# Altium schematic sheet Experiment 1 0.0.0.SchDoc
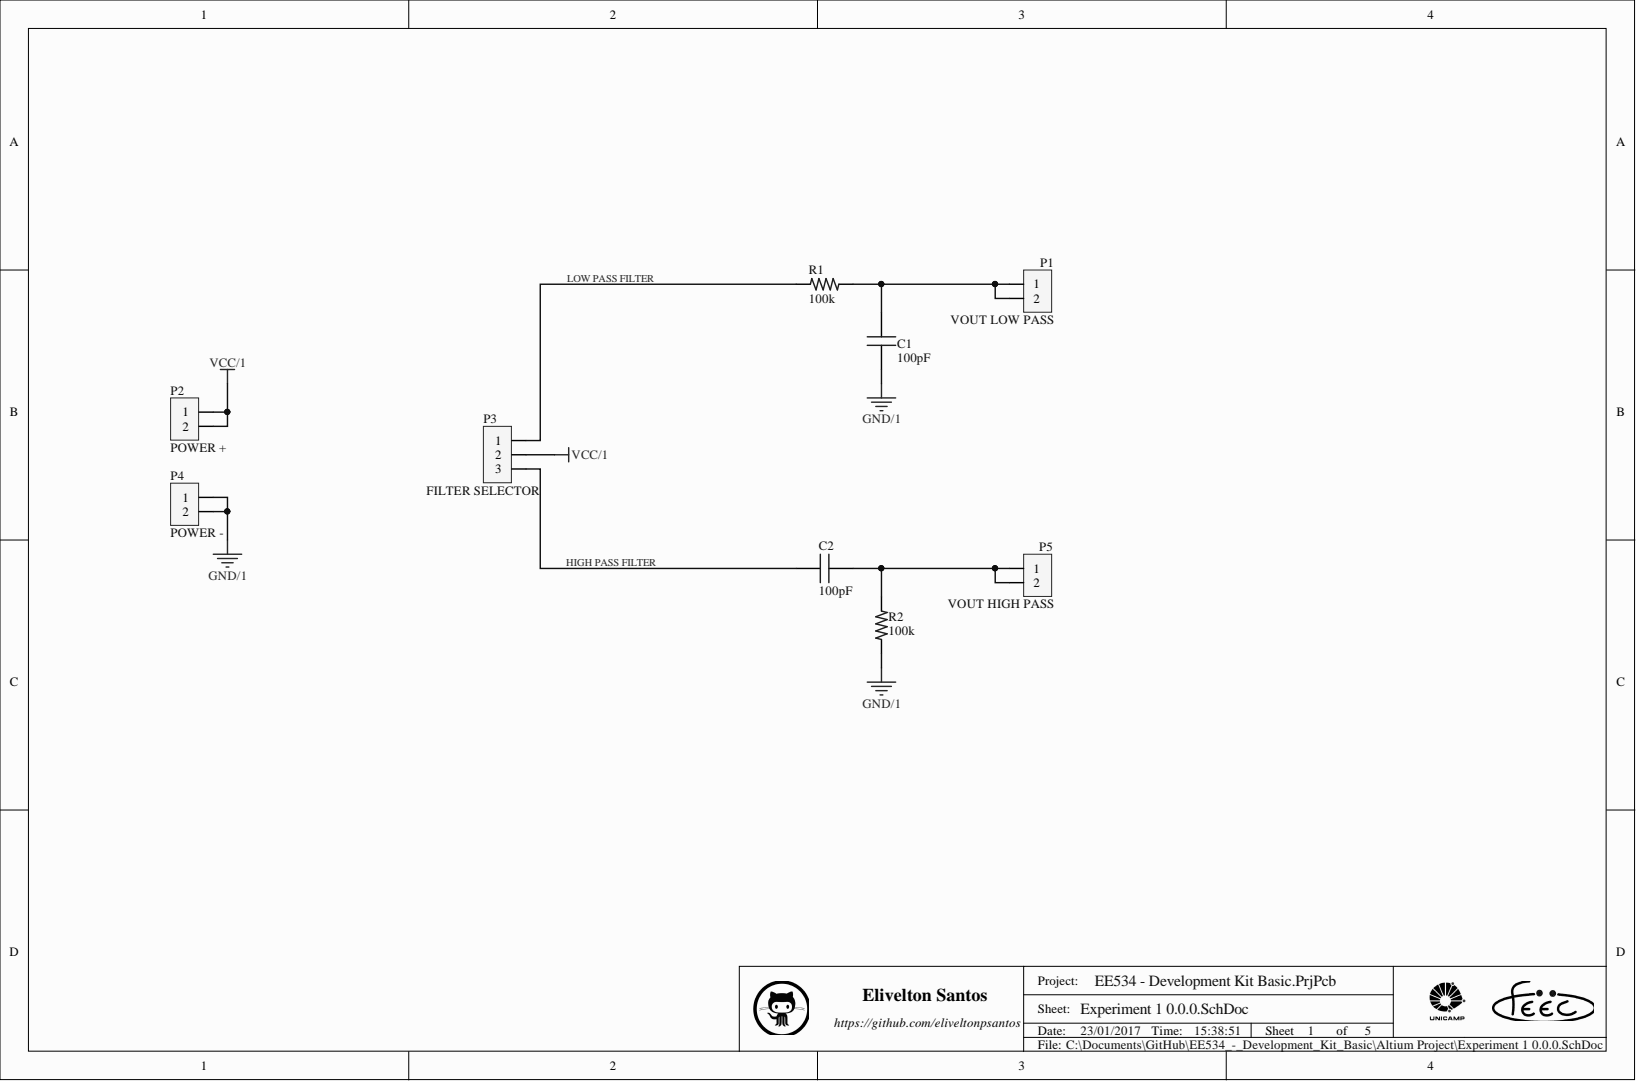
[diagram p0: Experiment 1 0.0.0.SchDoc]

## Parts
C1  100pF  [CAP-H5]
C2  100pF  [CAP-H5]
P1  VOUT LOW PASS  [PINHD-1X02 ONCE]
P2  POWER +  [PINHD-1X02 ONCE]
P3  FILTER SELECTOR  [PINHD-1X03]
P4  POWER -  [PINHD-1X02 ONCE]
P5  VOUT HIGH PASS  [PINHD-1X02 ONCE]
R1  100k  [R Axial 0.25]
R2  100k  [R Axial 0.25]

## Nets
GND/1: C1.2 P4.1 P4.2 R2.1
VCC/1: P2.1 P2.2 P3.2
NetC1_1: C1.1 P1.1 P1.2 R1.2
NetC2_1: C2.1 P3.3
NetC2_2: C2.2 P5.1 P5.2 R2.2
NetP3_1: P3.1 R1.1

## Library data of the parts
C1 C2 = K101K10X7RF5UH5: CAP CER 100PF 50V X7R RADIAL; Manufacturer: Vishay BC Components; Tolerance: ±10%; Capacitance: 100pF; Category: Capacitors; Component Kind: Standard; Library Name: Capacitors.IntLib; Manufacturer Part Number: K101K10X7RF5UH5; Package / Case: 0.142" L x 0.091" W (3.60mm x 2.30mm); Supplier 1: Digi-Key; Supplier Part Number 1: BC2657CT-ND; Voltage: 50V
P1 P2 P4 P5 = PIN HEADER 1X02 ONCE: CONN HEADER 2POS .100" STR TIN; Manufacturer: Molex, LLC; Manufacturer Part Number: 0901200122; Category: Connectors; Component Kind: Standard; Library Name: Connectors.IntLib; Supplier 1: Digi-Key; Supplier Part Number 1: WM8072-ND
P3 = PIN HEADER 1X03: CONN HEADER 3POS .100" STR TIN; Manufacturer: Molex, LLC; Manufacturer Part Number: 0901200123; Category: Connectors; Component Kind: Standard; Library Name: Connectors.IntLib; Supplier 1: Digi-Key; Supplier Part Number 1: WM8073-ND
R1 R2 = CFR-25JB-52-100K: RES 100K OHM 1/4W 5% AXIAL; Manufacturer: Yageo; Power (Watts): 0.25W, 1/4W; Resistance (Ohms): 10k; Category: Resistors; Component Kind: Standard; Library Name: Resistors.IntLib; Manufacturer Part Number: CFR-25JB-52-100K; Package / Case: Axial; Supplier 1: Digi-Key; Supplier Part Number 1: 100KQBK-ND; Tolerance: ±5%
